# Altium schematic sheet 5_BT8829C模组.SchDoc(5_BT8829C模组)
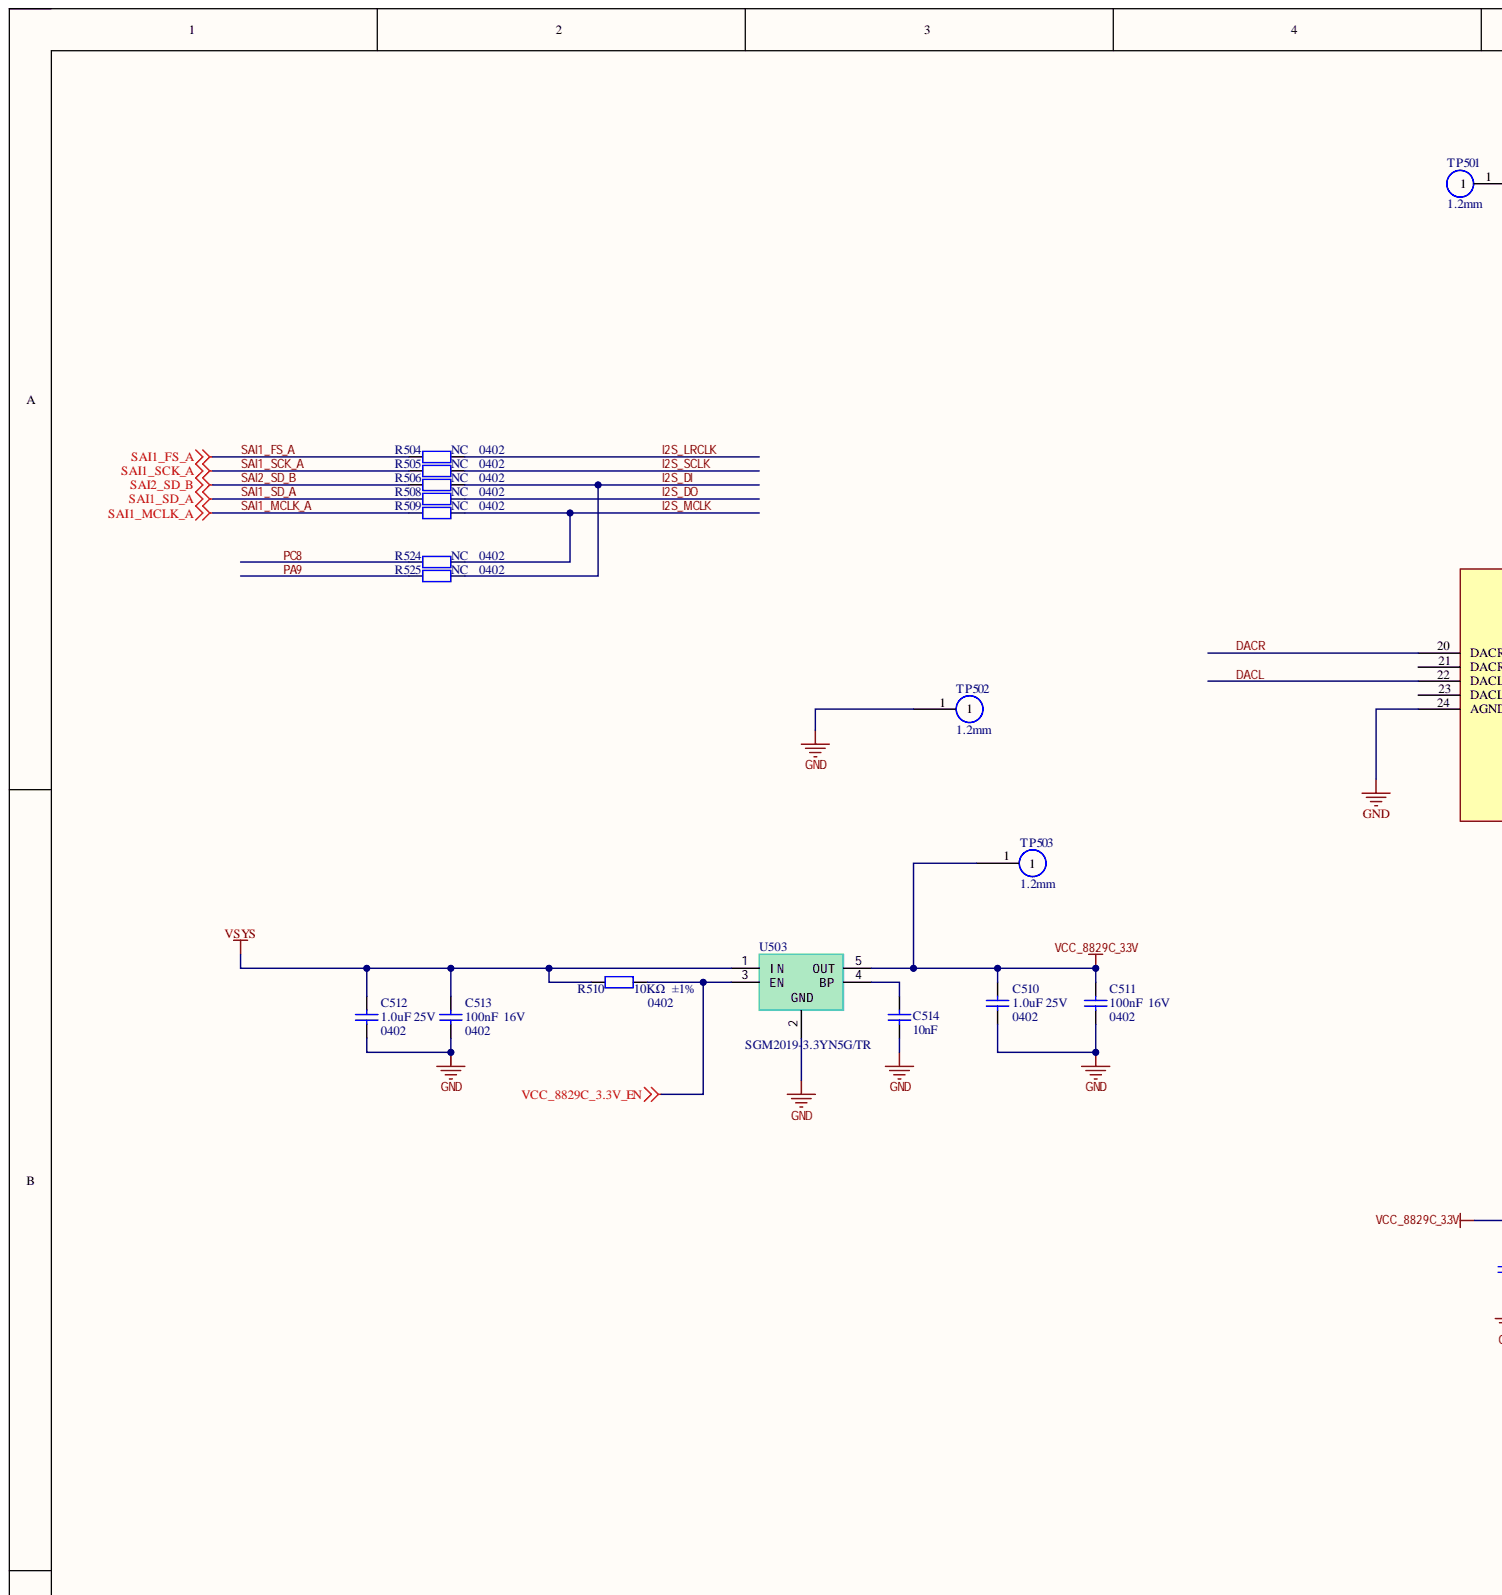
[diagram p0: 5_BT8829C模组.SchDoc, top left]
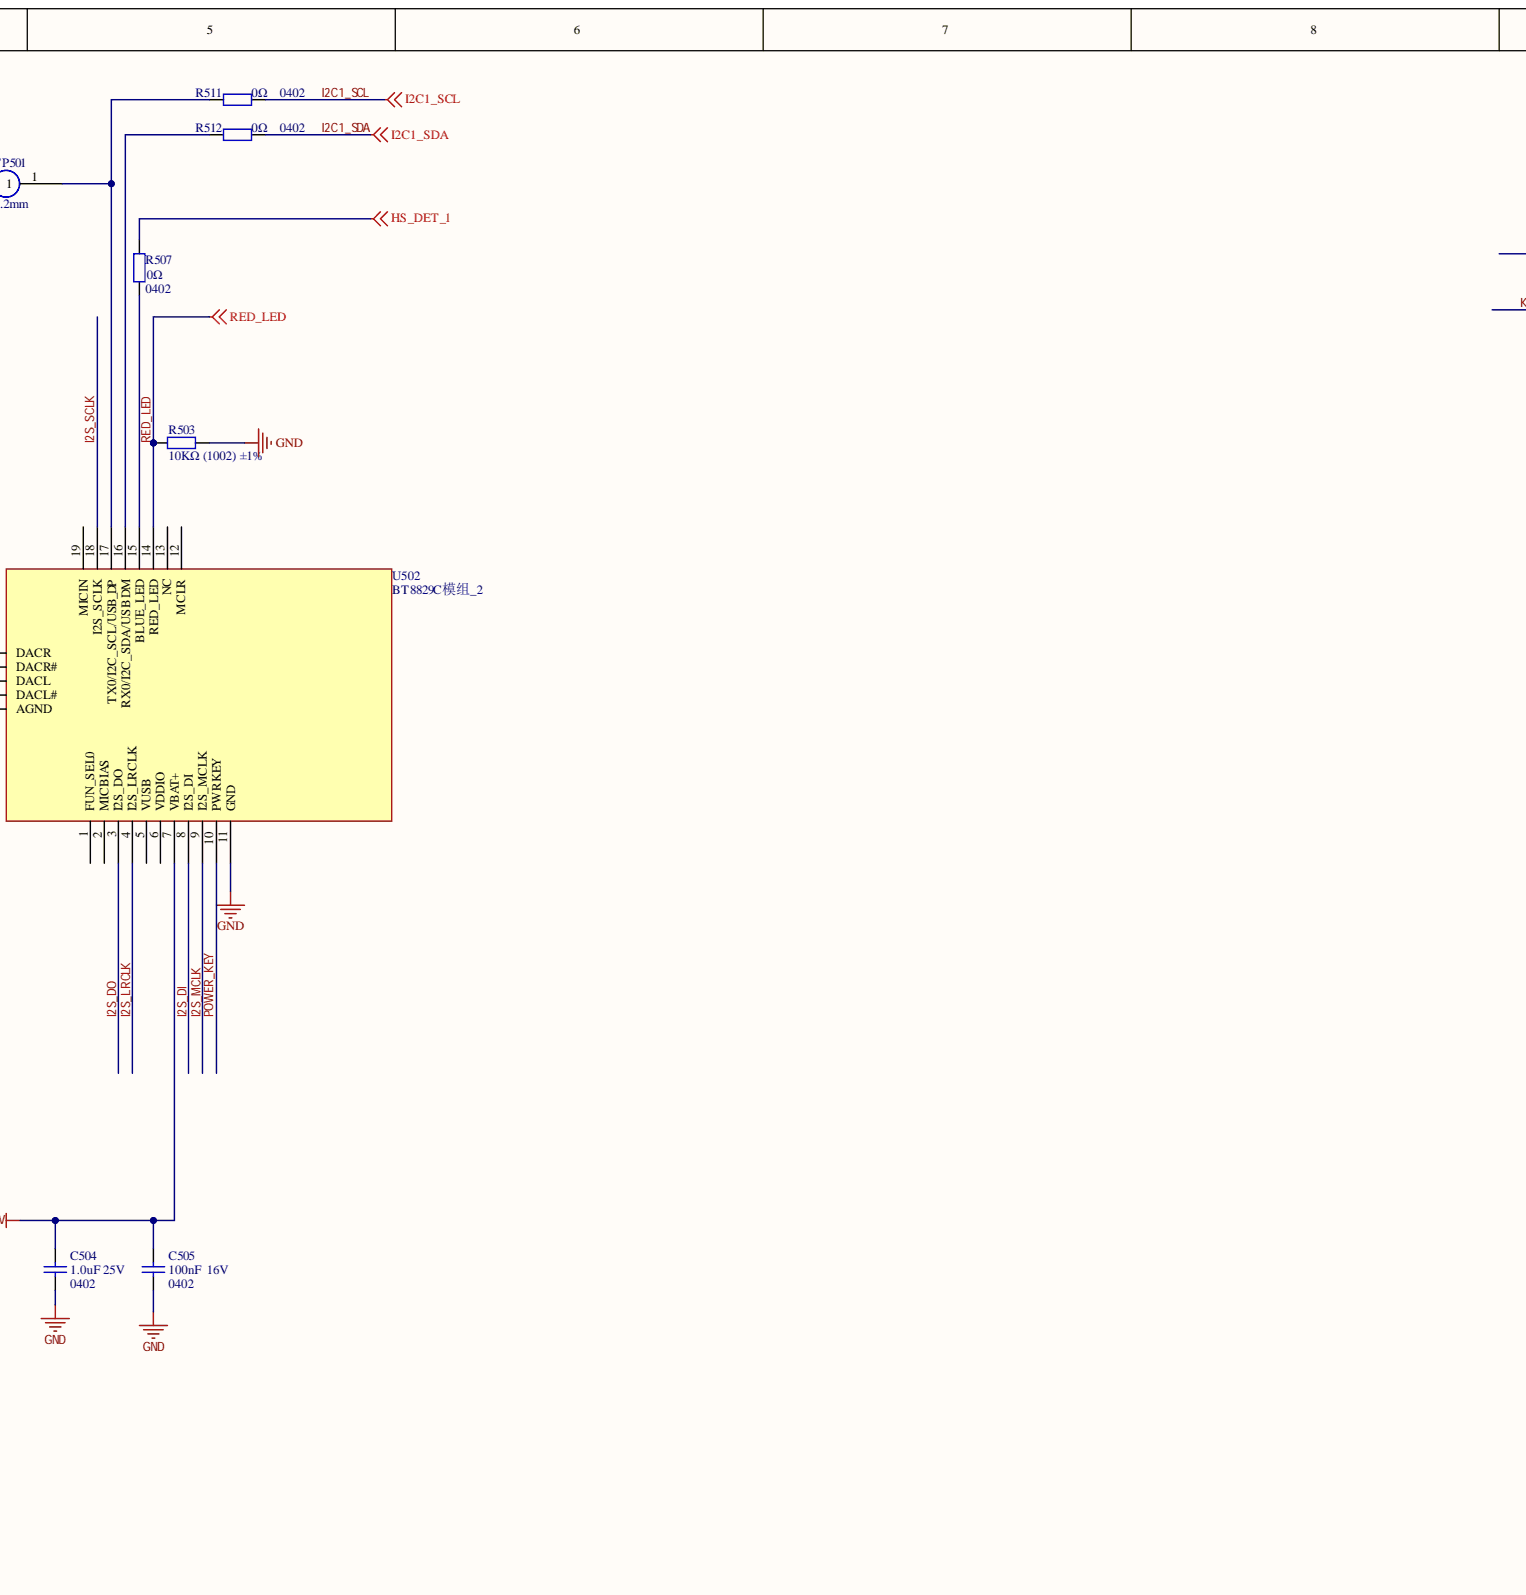
[diagram p1: 5_BT8829C模组.SchDoc, top centre]
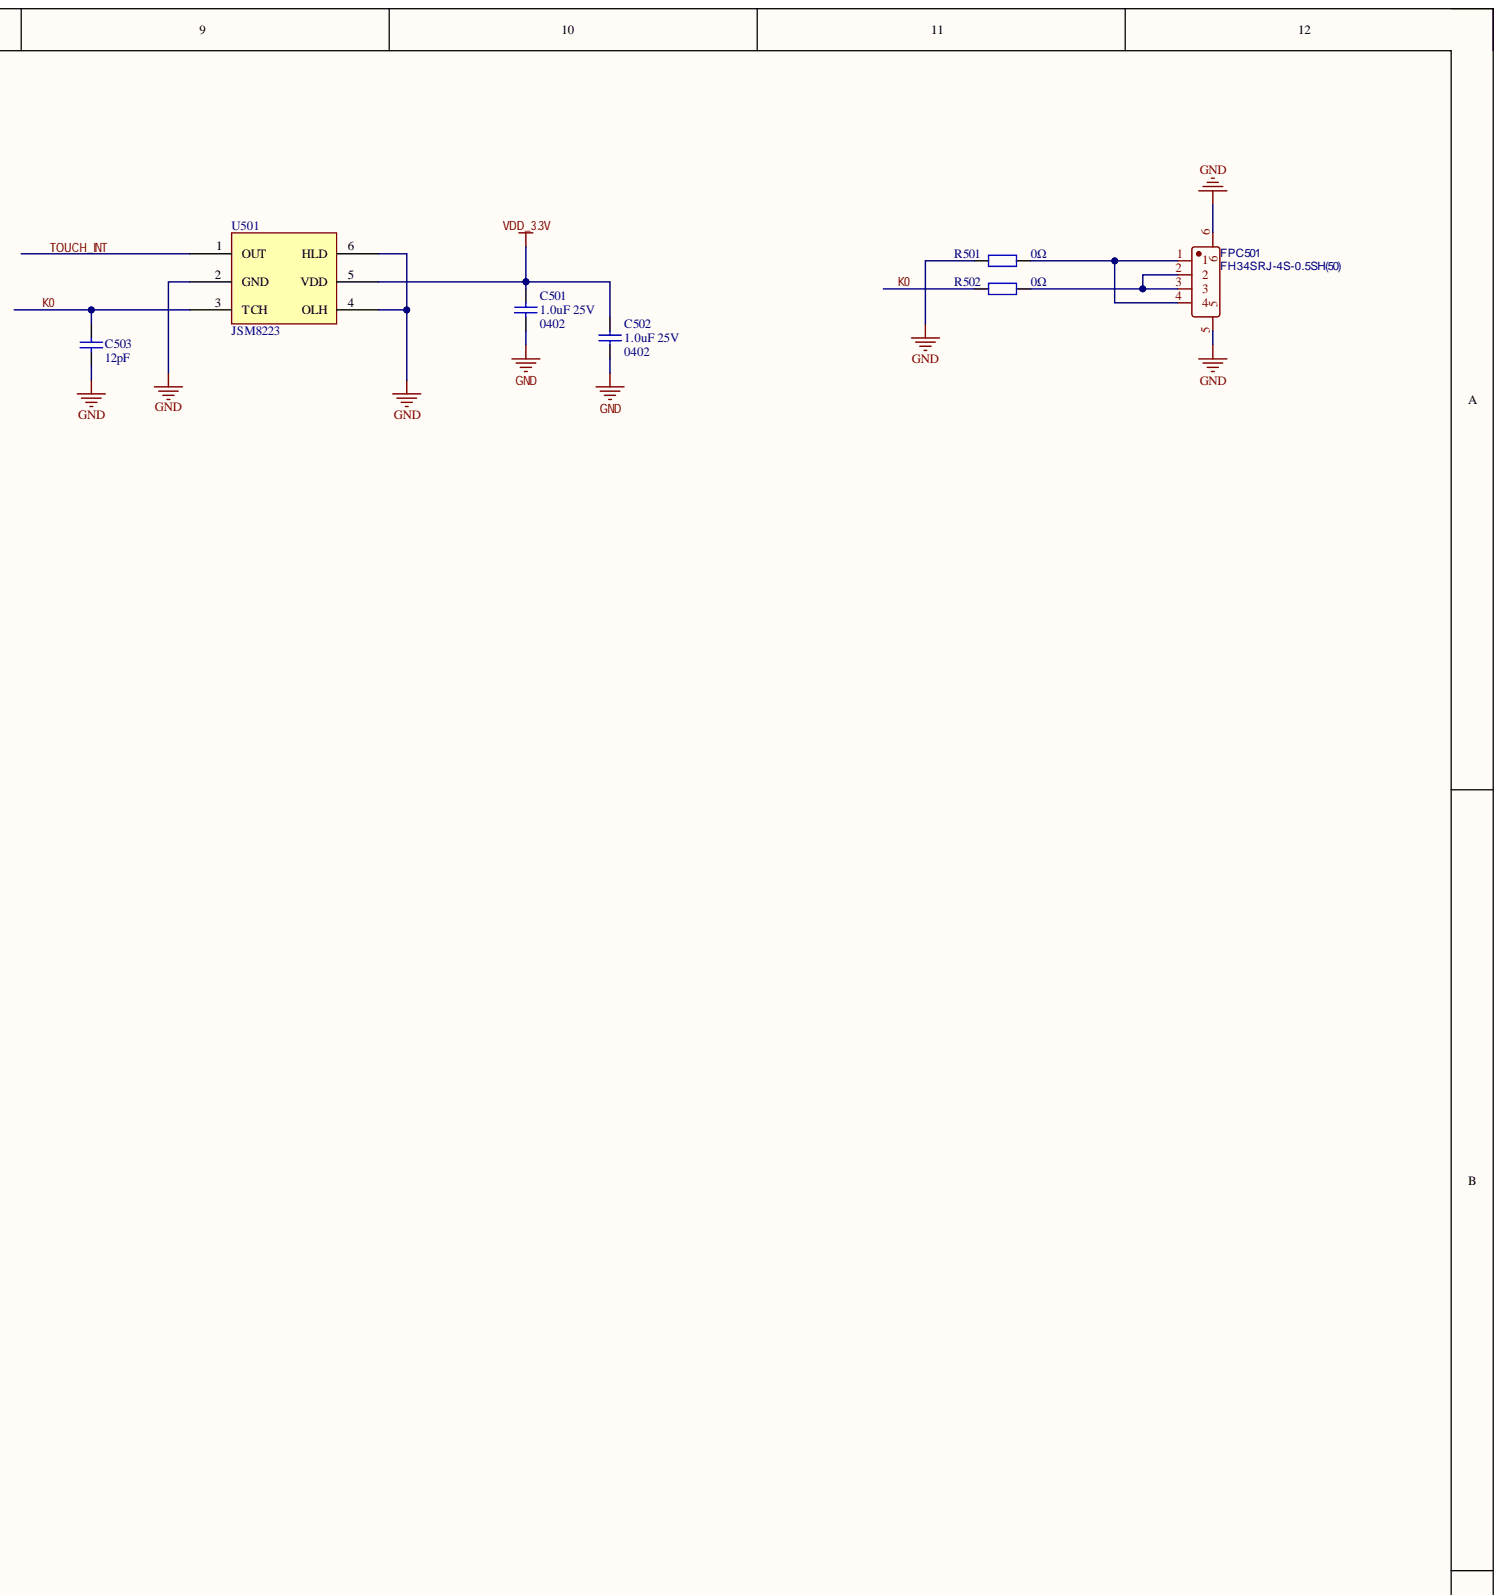
[diagram p2: 5_BT8829C模组.SchDoc, top right]
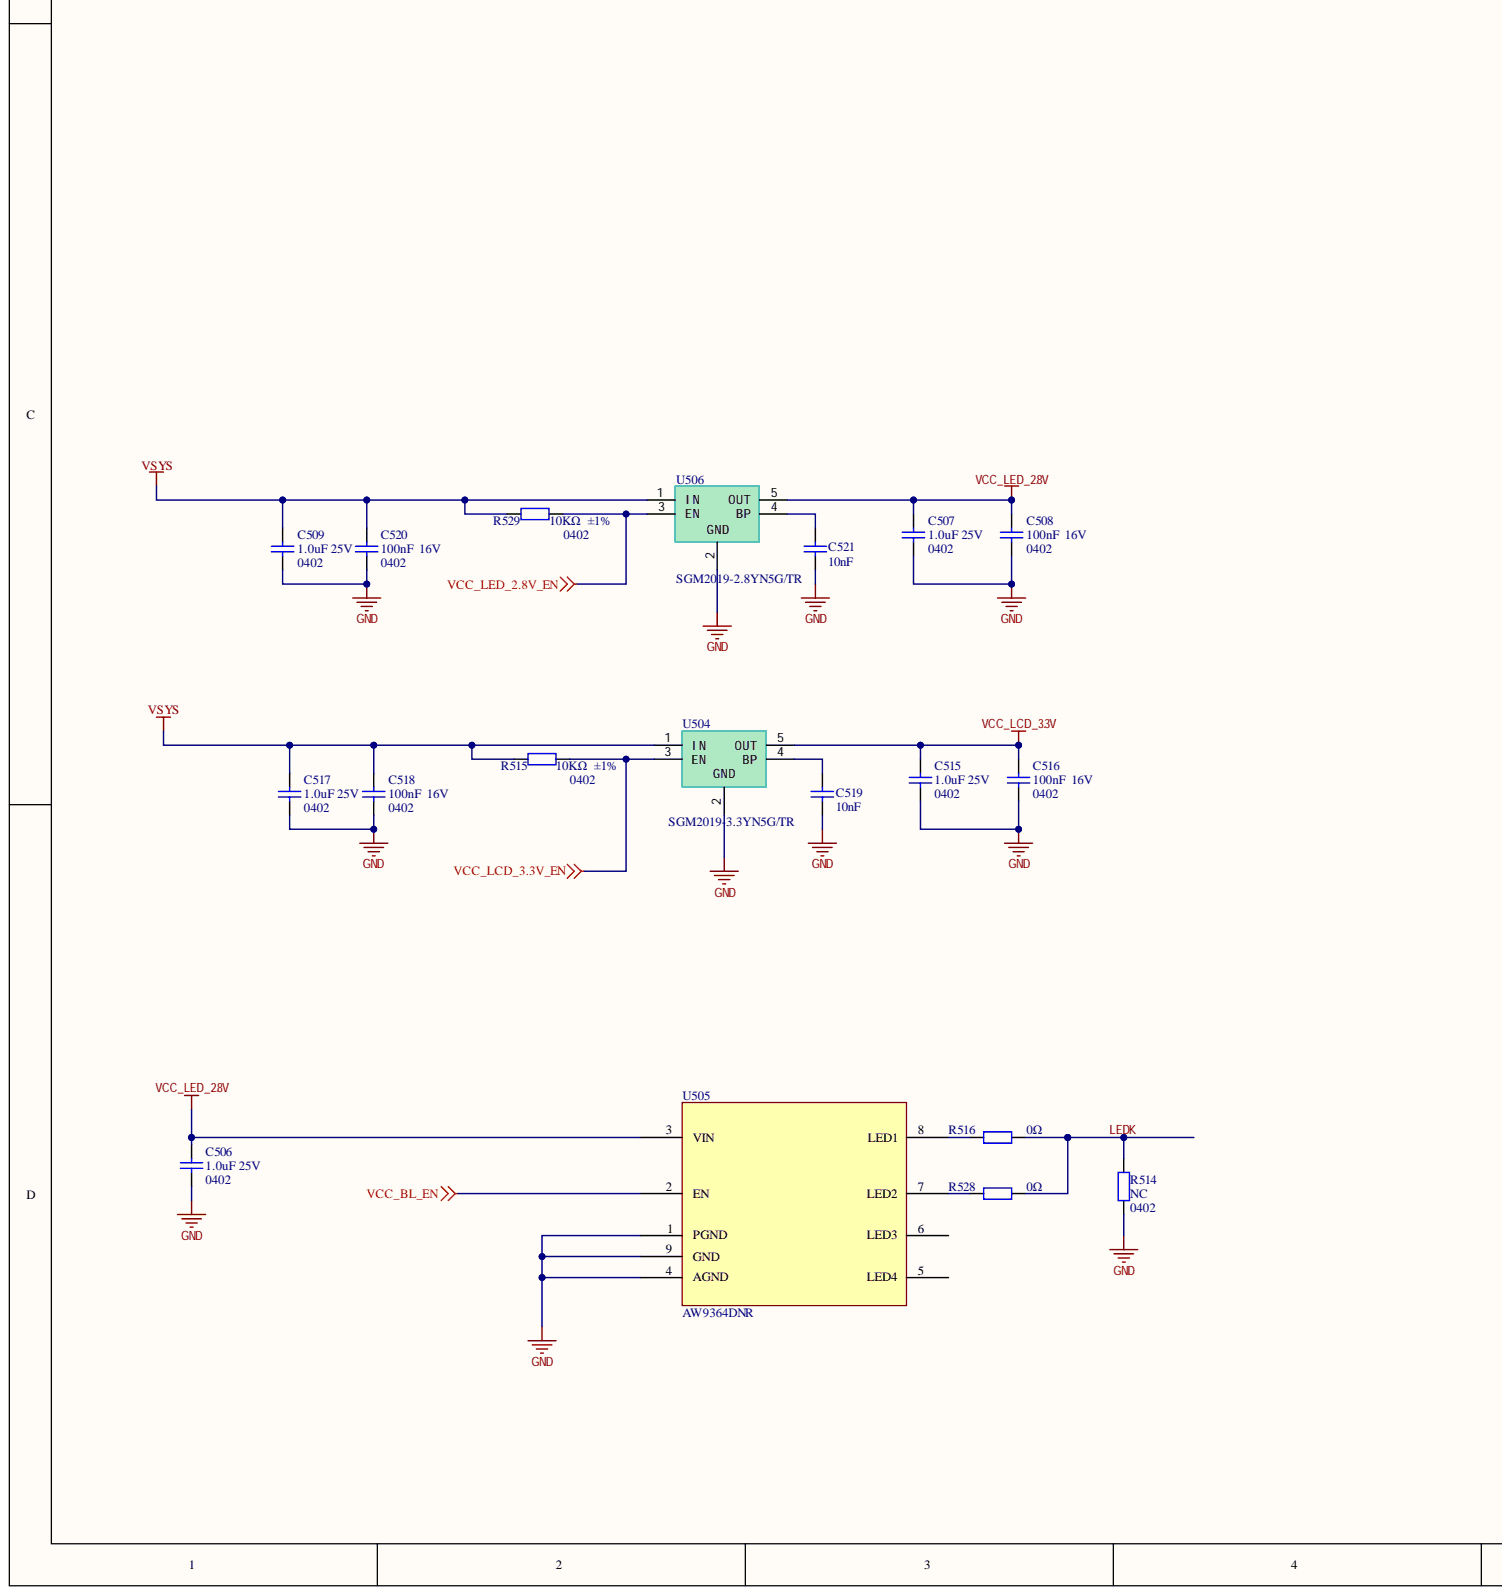
[diagram p3: 5_BT8829C模组.SchDoc, bottom left]
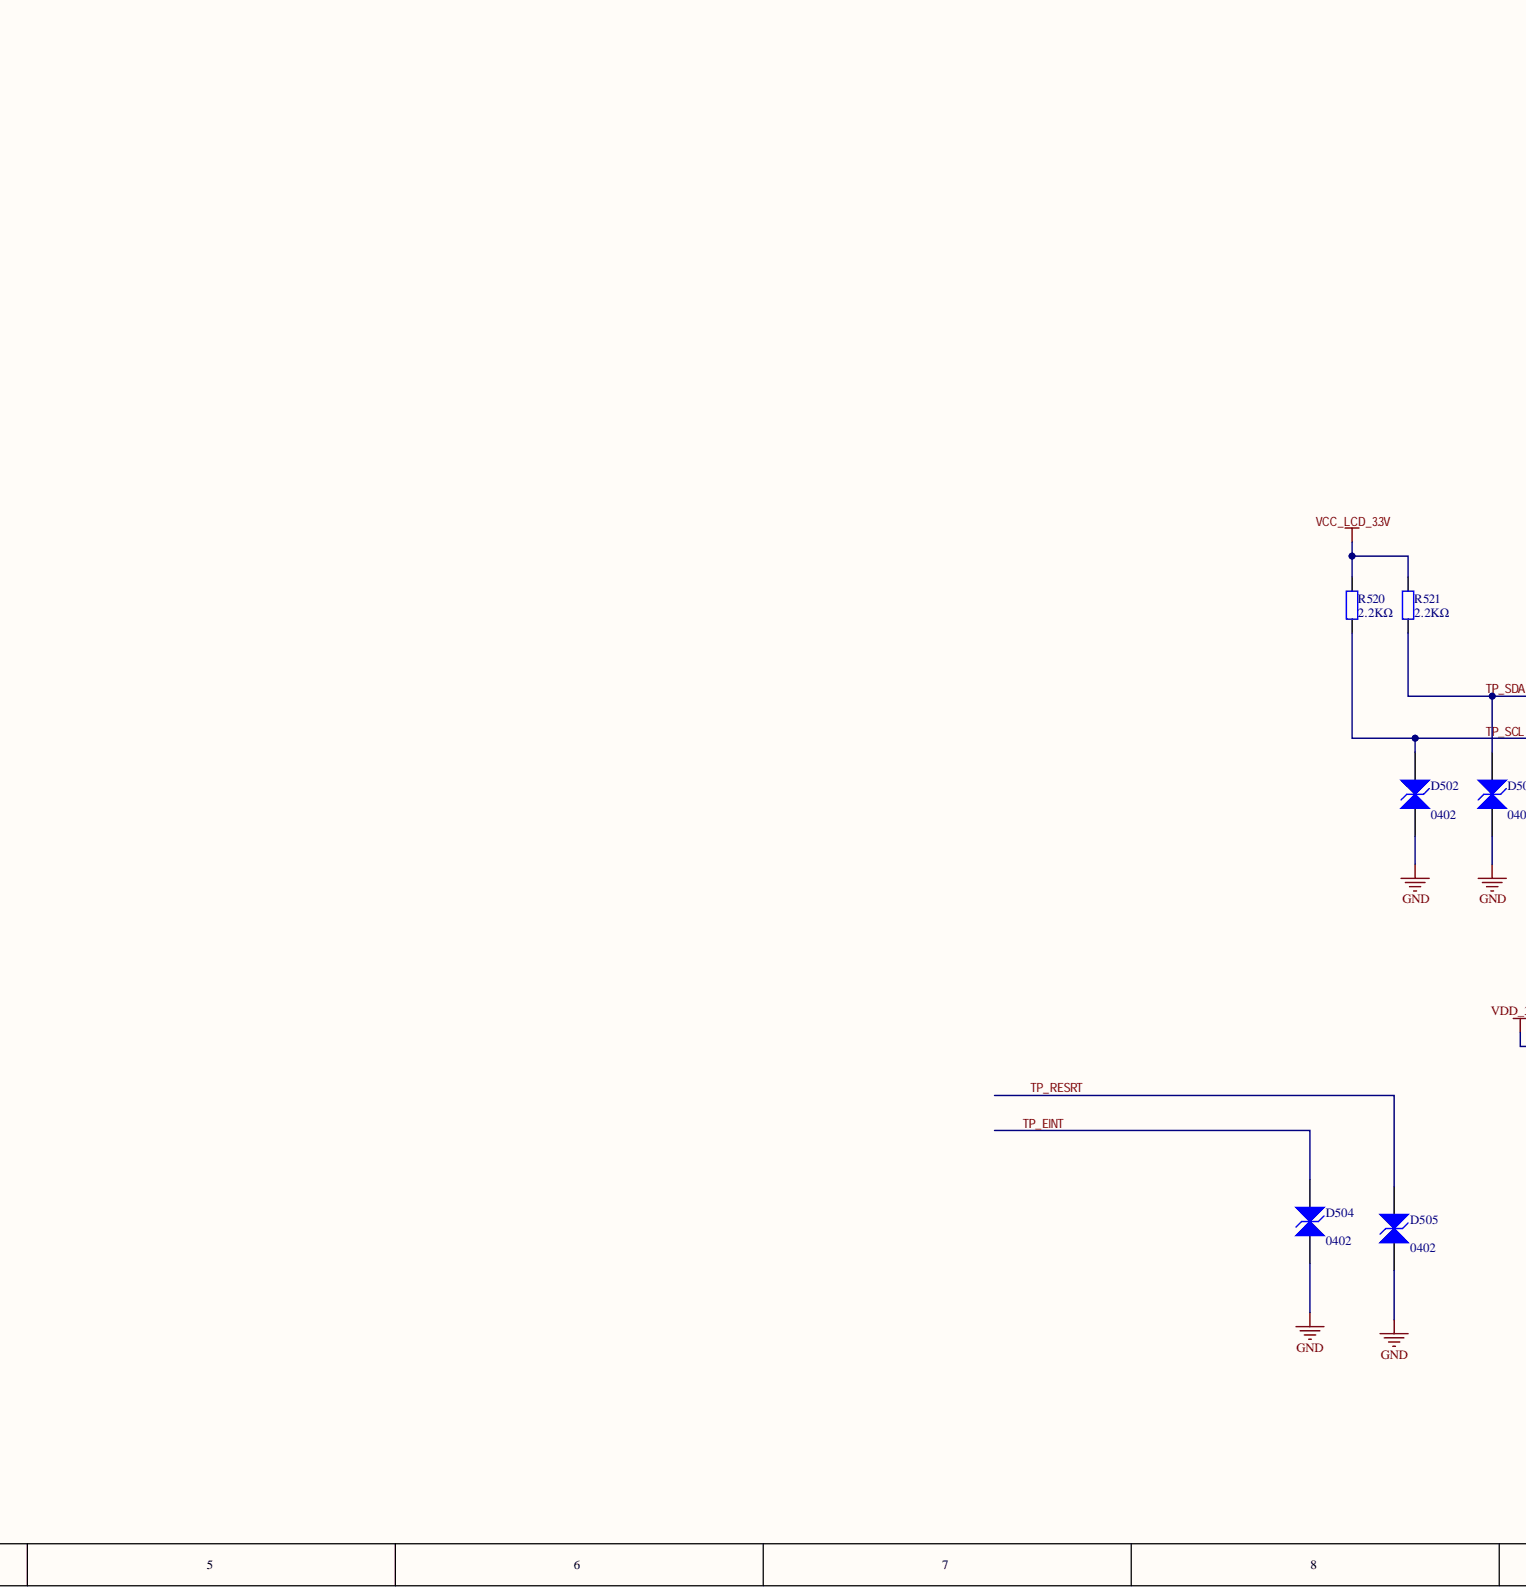
[diagram p4: 5_BT8829C模组.SchDoc, bottom centre]
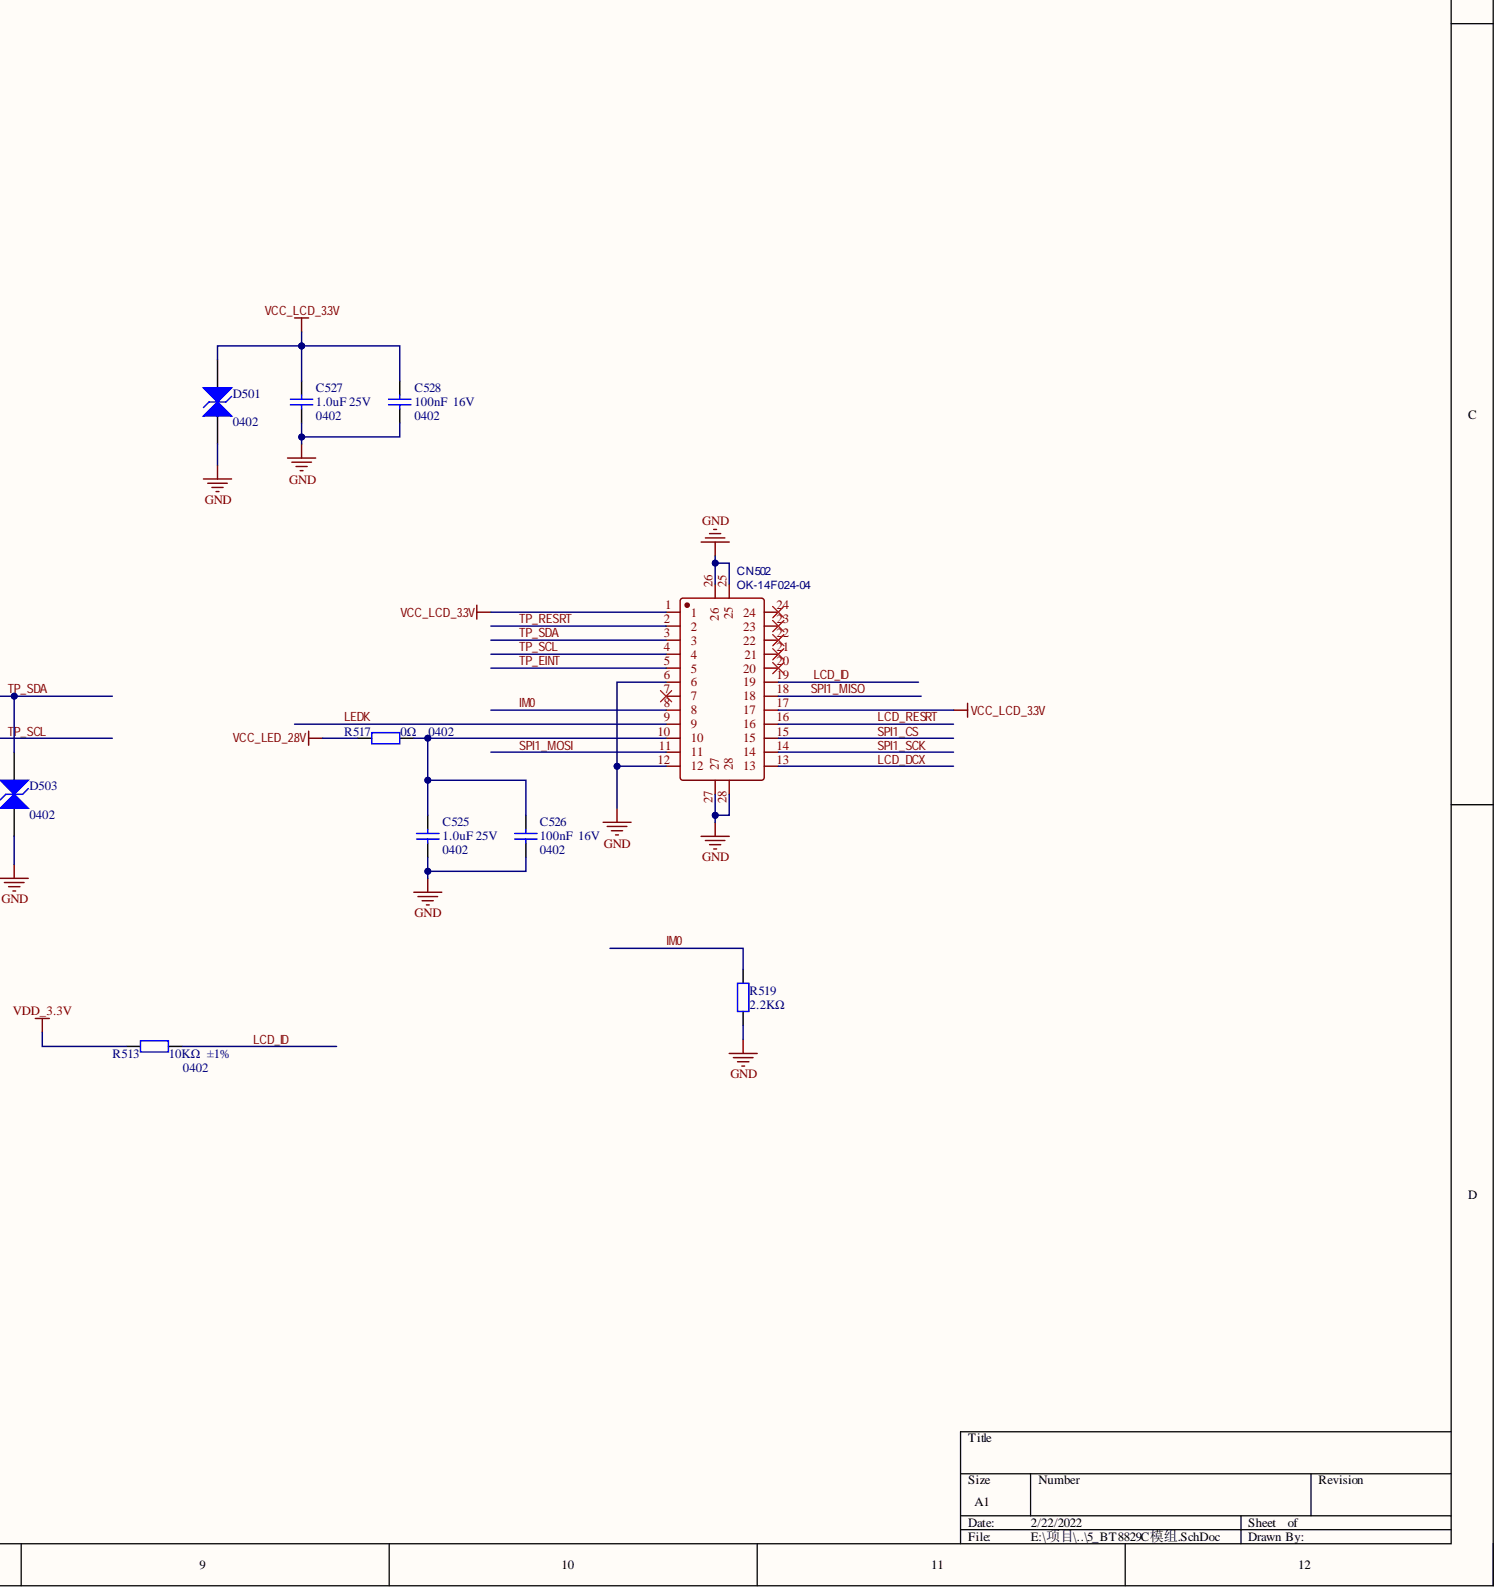
[diagram p5: 5_BT8829C模组.SchDoc, bottom right]

## Parts
C501  1.0uF 25V  Package: 0402  [0402_C]
C502  1.0uF 25V  Package: 0402  [0402_C]
C503  12pF  [0402_C]
C504  1.0uF 25V  Package: 0402  [0402_C]
C505  100nF  16V  Package: 0402  [0402_C]
C506  1.0uF 25V  Package: 0402  [0402_C]
C507  1.0uF 25V  Package: 0402  [0402_C]
C508  100nF  16V  Package: 0402  [0402_C]
C509  1.0uF 25V  Package: 0402  [0402_C]
C510  1.0uF 25V  Package: 0402  [0402_C]
C511  100nF  16V  Package: 0402  [0402_C]
C512  1.0uF 25V  Package: 0402  [0402_C]
C513  100nF  16V  Package: 0402  [0402_C]
C514  10nF  [0402_C]
C515  1.0uF 25V  Package: 0402  [0402_C]
C516  100nF  16V  Package: 0402  [0402_C]
C517  1.0uF 25V  Package: 0402  [0402_C]
C518  100nF  16V  Package: 0402  [0402_C]
C519  10nF  [0402_C]
C520  100nF  16V  Package: 0402  [0402_C]
C521  10nF  [0402_C]
C525  1.0uF 25V  Package: 0402  [0402_C]
C526  100nF  16V  Package: 0402  [0402_C]
C527  1.0uF 25V  Package: 0402  [0402_C]
C528  100nF  16V  Package: 0402  [0402_C]
CN502  OK-14F024-04  [CONN-SMD_24P-P0.40_SSTIZ_SS2564024F]
D501  Package: 0402  [DFN1006]  (hidden comment: RCLAMP0521P-N)
D502  Package: 0402  [DFN1006]  (hidden comment: RCLAMP0521P-N)
D503  Package: 0402  [DFN1006]  (hidden comment: RCLAMP0521P-N)
D504  Package: 0402  [DFN1006]  (hidden comment: RCLAMP0521P-N)
D505  Package: 0402  [DFN1006]  (hidden comment: RCLAMP0521P-N)
FPC501  FH34SRJ-4S-0.5SH(50)  [FPC-SMD_FH34SRJ-4S-0.5SH-50]
R501  0Ω  [0402_R]
R502  0Ω  [0402_R]
R503  10KΩ (1002) ±1%  [0402_R]
R504  NC  Package: 0402  [0402_R]
R505  NC  Package: 0402  [0402_R]
R506  NC  Package: 0402  [0402_R]
R507  0Ω  Package: 0402  [0402_R]
R508  NC  Package: 0402  [0402_R]
R509  NC  Package: 0402  [0402_R]
R510  10KΩ  ±1%  Package: 0402  [0402_R]
R511  0Ω  Package: 0402  [0402_R]
R512  0Ω  Package: 0402  [0402_R]
R513  10KΩ  ±1%  Package: 0402  [0402_R]
R514  NC  Package: 0402  [0402_R]
R515  10KΩ  ±1%  Package: 0402  [0402_R]
R516  0Ω  [0402_R]
R517  0Ω  Package: 0402  [0402_R]
R519  2.2KΩ  [0402_R]
R520  2.2KΩ  [0402_R]
R521  2.2KΩ  [0402_R]
R524  NC  Package: 0402  [0402_R]
R525  NC  Package: 0402  [0402_R]
R528  0Ω  [0402_R]
R529  10KΩ  ±1%  Package: 0402  [0402_R]
TP501  1.2mm  [1.2mm测试点]
TP502  1.2mm  [1.2mm测试点]
TP503  1.2mm  [1.2mm测试点]
U501  JSM8223  [JSM8223]
U502  BT8829C模组_2  [8829C-MODU1_2]
U503  SGM2019-3.3YN5G/TR  [SGM2019-3.3YN5G/TR]
U504  SGM2019-3.3YN5G/TR  [SGM2019-3.3YN5G/TR]
U505  AW9364DNR  [AW9364DNR]
U506  SGM2019-2.8YN5G/TR  [SGM2019-2.8YN5G/TR]

## Nets
GND: C501.1 C502.1 C503.1 C504.1 C505.1 C506.1 C507.1 C508.1 C509.1 C510.1 C511.1 C512.1 C513.1 C514.1 C515.1 C516.1 C517.1 C518.1 C519.1 C520.1 C521.1 C525.1 C526.1 C527.1 C528.1 CN502.6 CN502.12 CN502.25 CN502.26 CN502.27 CN502.28 D501.1 D502.1 D503.1 D504.1 D505.1 FPC501.5 FPC501.6 R501.1 R503.1 R514.1 R519.1 TP502.1 U501.2(GND) U501.4(OLH) U501.6(HLD) U502.11(GND) U502.24(AGND) U503.2 U504.2 U505.1(PGND) U505.4(AGND) U505.9(GND) U506.2
HS_DET_1: R507.2
VCC_8829C_3.3V: C504.2 C505.2 C510.2 C511.2 TP503.1 U502.7 U503.5(OUT)
VCC_8829C_3.3V_EN: R510.1 U503.3(EN)
VCC_BL_EN: U505.2(EN)
VCC_LCD_3.3V: C515.2 C516.2 C527.2 C528.2 CN502.1 CN502.17 D501.2 R520.2 R521.2 U504.5(OUT)
VCC_LCD_3.3V_EN: R515.1 U504.3(EN)
VCC_LED_2.8V: C506.2 C507.2 C508.2 R517.2 U505.3(VIN) U506.5(OUT)
VCC_LED_2.8V_EN: R529.1 U506.3(EN)
VDD_3.3V: C501.2 C502.2 R513.2 U501.5(VDD)
VSYS: C509.2 C512.2 C513.2 C517.2 C518.2 C520.2 R510.2 R515.2 R529.2 U503.1(IN) U504.1(IN) U506.1(IN)
DACL: U502.22(DACL)
DACR: U502.20(DACR)
I2C1_SCL: R511.1
I2C1_SDA: R512.1
I2S_DI: R506.2 R525.2 U502.8(I2S_DI)
I2S_DO: R508.2 U502.3(I2S_DO)
I2S_LRCLK: R504.2 U502.4
I2S_MCLK: R509.2 R524.2 U502.9(I2S_MCLK)
I2S_SCLK: R505.2 U502.18(I2S_SCLK)
IM0: CN502.8 R519.2
K0: C503.2 R502.1 U501.3(TCH)
LCD_DCX: CN502.13
LCD_ID: CN502.19 R513.1
LCD_RESRT: CN502.16
LEDK: CN502.9 R514.2 R516.2 R528.2
PA9: R525.1
PC8: R524.1
POWER_KEY: U502.10(PWRKEY)
RED_LED: R503.2 U502.14
SAI1_FS_A: R504.1
SAI1_MCLK_A: R509.1
SAI1_SCK_A: R505.1
SAI1_SD_A: R508.1
SAI2_SD_B: R506.1
SPI1_CS: CN502.15
SPI1_MISO: CN502.18
SPI1_MOSI: CN502.11
SPI1_SCK: CN502.14
TOUCH_INT: U501.1(OUT)
TP_EINT: CN502.5 D504.2
TP_RESRT: CN502.2 D505.2
TP_SCL: CN502.4 D502.2 R520.1
TP_SDA: CN502.3 D503.2 R521.1
NetC514_2: C514.2 U503.4(BP)
NetC519_2: C519.2 U504.4(BP)
NetC521_2: C521.2 U506.4(BP)
NetC525_2: C525.2 C526.2 CN502.10 R517.1
NetFPC501_1: FPC501.1 FPC501.4 R501.2
NetFPC501_2: FPC501.2 FPC501.3 R502.2
NetR507_1: R507.1 U502.15(BLUE_LED)
NetR511_2: R511.2 TP501.1 U502.17
NetR512_2: R512.2 U502.16(RX0/I2C_SDA/USB_DM)
NetR516_1: R516.1 U505.8(LED1)
NetR528_1: R528.1 U505.7(LED2)

Not connected: CN502 7 20 21 22 23 24; U502 1 2(MICBIAS) 5(VUSB) 6(VDDIO) 12(MCLR) 13(NC) 19(MICIN) 21(DACR#) 23(DACL#); U505 5(LED4) 6(LED3)

## Library data of the parts
C501 C502 C504 C506 C507 C509 C510 C512 C515 C517 C525 C527 = 0402 1.0uF (105) 10% 25V: 贴片电容; Component Kind: Standard; ComponentLink1Description: 供应商链接; ComponentLink1URL: http://www.szlcsc.com/product/details_53938.html; Library Name: Miscellaneous Devices LC.IntLib; Supplier: LC; SuppliersPartNumber: C52923
C503 = 0402 12pF (120) 5% 50V: 贴片电容; Component Kind: Standard; ComponentLink1Description: 供应商链接; ComponentLink1URL: http://www.szlcsc.com/product/details_1899.html; Library Name: Miscellaneous Devices LC.IntLib; Package: 0402; Supplier: LC; SuppliersPartNumber: C1547
C505 C508 C511 C513 C516 C518 C520 C526 C528 = 0402 100nF (104) 10% 16V: 贴片电容; Component Kind: Standard; ComponentLink1Description: 供应商链接; ComponentLink1URL: http://www.szlcsc.com/product/details_1877.html; Library Name: Miscellaneous Devices LC.IntLib; Supplier: LC; SuppliersPartNumber: C1525
C514 C519 C521 = 0402 10nF (103) 10% 50V: 贴片电容; Component Kind: Standard; ComponentLink1Description: 供应商链接; ComponentLink1URL: http://www.szlcsc.com/product/details_15869.html; Library Name: Miscellaneous Devices LC.IntLib; Package: 0402; Supplier: LC; SuppliersPartNumber: C15195
CN502 = OK-14F024-04: BOM_Manufacturer: SSTIZ(盛世台圳); BOM_Manufacturer Part: SS2564024F; Contributor: LCSC; BOM_JLCPCB Part Class: Extended Part; BOM_Supplier: LCSC; BOM_Supplier Part: C2911633; Component Kind: Standard; Library Name: AD_LIB.SchLib; spicePre: C; spiceSymbolName: SS2564024F
D501-D505 = TVS_RCLAMP0521P-N: TVS  BORN伯恩半导体  RCLAMP0521P-N; Component Kind: Standard; ComponentLink1Description: 供应商链接; ComponentLink1URL: http://www.szlcsc.com/product/details_79530.html; Library Name: AD_LIB.SchLib; Supplier: LC; SuppliersPartNumber: C316043
FPC501 = FH34SRJ-4S-0.5SH(50): BOM_Manufacturer: HRS(广濑); Contributor: LCSC; BOM_JLCPCB Part Class: Extended Part; BOM_Manufacturer Part: FH34SRJ-4S-0.5SH(50); BOM_Supplier: LCSC; BOM_Supplier Part: C596732; Component Kind: Standard; Library Name: AD_LIB.SchLib; spicePre: F; spiceSymbolName: FH34SRJ-4S-0.5SH(50)
R501 R502 R507 R511 R512 R516 R517 R528 = 0402 0Ω (0R0) 1%: 贴片电阻; Component Kind: Standard; ComponentLink1Description: 供应商链接; ComponentLink1URL: http://www.szlcsc.com/product/details_17853.html; Library Name: Miscellaneous Devices LC.IntLib; Supplier: LC; SuppliersPartNumber: C17168
  R501 R502 R516 R528: Package: 0402
R503 R510 R513 R515 R529 = 0402 10KΩ (1002) 1%: 贴片电阻; Component Kind: Standard; ComponentLink1Description: 供应商链接; ComponentLink1URL: http://www.szlcsc.com/product/details_26487.html; Library Name: Miscellaneous Devices LC.IntLib; Supplier: LC; SuppliersPartNumber: C25744
  R503: Package: 0402
R504-R506 R508 R509 R514 R524 R525 = NC_0402贴片电阻: 贴片电阻; Component Kind: Standard; ComponentLink1Description: 供应商链接; ComponentLink1URL: http://www.szlcsc.com/product/details_26498.html; Library Name: AD_LIB.IntLib
R519-R521 = 0402 2.2KΩ (2201) 1%: 贴片电阻; Component Kind: Standard; ComponentLink1Description: 供应商链接; ComponentLink1URL: http://www.szlcsc.com/product/details_26622.html; Library Name: Miscellaneous Devices LC.IntLib; Package: 0402; Supplier: LC; SuppliersPartNumber: C25879
TP501-TP503 = 1.2mm测试点: Component Kind: Standard; Library Name: AD_LIB.IntLib
U503 U504 = SGM2019-3.3YN5G/TR: 品　　牌： SGMICRO(圣邦微)  厂家型号： SGM2019-3.3YN5G/TR  商品编号： C23613  封装： SOT-23-5; Component Kind: Standard; Library Name: AD_LIB.SchLib
U506 = SGM2019-2.8YN5G/TR: 品　　牌： SGMICRO(圣邦微)  厂家型号： SGM2019-2.8YN5G/TR  商品编号： C192171  封装： SOT-23-5; Component Kind: Standard; Library Name: AD_LIB.IntLib
U501 = JSM8223: Component Kind: Standard; Library Name: AD_LIB.SchLib
U502 = BT8829C模组_2: Component Kind: Standard; Library Name: AD_LIB.SchLib
U505 = AW9364DNR: Component Kind: Standard; Library Name: AD_LIB.SchLib
